# Altium schematic sheet Controle Moteurs.SchDoc
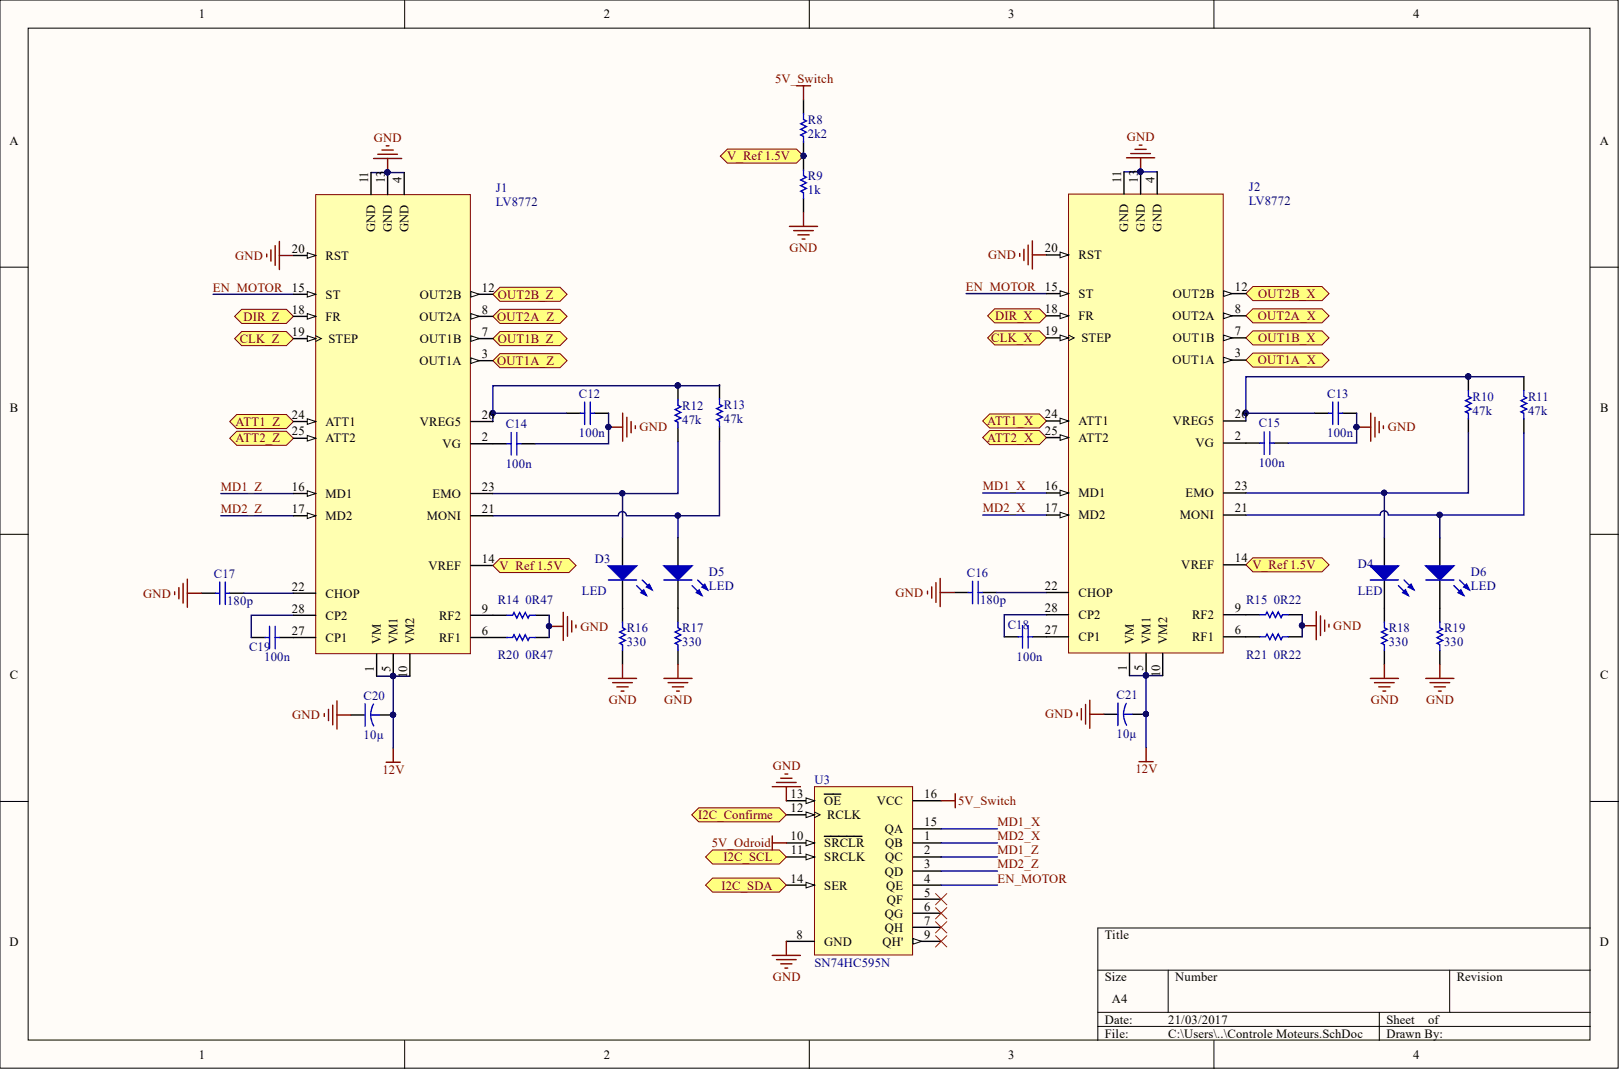
[diagram p0: Controle Moteurs.SchDoc]

## Parts
C12  value 100n  [RAD-0.3]  (hidden comment: Cap)
C13  value 100n  [RAD-0.3]  (hidden comment: Cap)
C14  value 100n  [RAD-0.3]  (hidden comment: Cap)
C15  value 100n  [RAD-0.3]  (hidden comment: Cap)
C16  value 180p  [RAD-0.3]  (hidden comment: Cap)
C17  value 180p  [RAD-0.3]  (hidden comment: Cap)
C18  value 100n  [RAD-0.3]  (hidden comment: Cap)
C19  value 100n  [RAD-0.3]  (hidden comment: Cap)
C20  value 10µ  [CAPR5-4X5]  (hidden comment: Cap2)
C21  value 10µ  [CAPR5-4X5]  (hidden comment: Cap2)
D3  LED  [C0805]
D4  LED  [C0805]
D5  LED  [C0805]
D6  LED  [C0805]
J1  LV8772
J2  LV8772
R8  value 2k2  [AXIAL-0.3]  (hidden comment: Res1)
R9  value 1k  [AXIAL-0.3]  (hidden comment: Res1)
R10  value 47k  [AXIAL-0.3]  (hidden comment: Res1)
R11  value 47k  [AXIAL-0.3]  (hidden comment: Res1)
R12  value 47k  [AXIAL-0.3]  (hidden comment: Res1)
R13  value 47k  [AXIAL-0.3]  (hidden comment: Res1)
R14  value 0R47  [AXIAL-0.3]  (hidden comment: Res1)
R15  value 0R22  [AXIAL-0.3]  (hidden comment: Res1)
R16  value 330  [AXIAL-0.3]  (hidden comment: Res1)
R17  value 330  [AXIAL-0.3]  (hidden comment: Res1)
R18  value 330  [AXIAL-0.3]  (hidden comment: Res1)
R19  value 330  [AXIAL-0.3]  (hidden comment: Res1)
R20  value 0R47  [AXIAL-0.3]  (hidden comment: Res1)
R21  value 0R22  [AXIAL-0.3]  (hidden comment: Res1)
U3  SN74HC595N  [N016]

## Ports
ATT1_X, ATT1_Z, ATT2_X, ATT2_Z, CLK_X, CLK_Z, DIR_X, DIR_Z, I2C_CONFIRME, I2C_SCL, I2C_SDA, OUT1A_X, OUT1A_Z, OUT1B_X, OUT1B_Z, OUT2A_X, OUT2A_Z, OUT2B_X, OUT2B_Z, V_REF 1.5V

## Nets
5V_ODROID: U3.10(SRCLR)
5V_SWITCH: R8.1 U3.16(VCC)
12V: C20.2 C21.2 J1.1(VM) J1.5(VM1) J1.10(VM2) J2.1(VM) J2.5(VM1) J2.10(VM2)
GND: C12.2 C13.2 C14.1 C15.1 C16.2 C17.2 C20.1 C21.1 J1.4(GND) J1.11(GND) J1.13(GND) J1.20(RST) J2.4(GND) J2.11(GND) J2.13(GND) J2.20(RST) R9.2 R14.1 R15.1 R16.2 R17.2 R18.2 R19.2 R20.1 R21.1 U3.8(GND) U3.13(OE)
ATT1_X: J2.24(ATT1) ; port ATT1_X
ATT1_Z: J1.24(ATT1) ; port ATT1_Z
ATT2_X: J2.25(ATT2) ; port ATT2_X
ATT2_Z: J1.25(ATT2) ; port ATT2_Z
CLK_X: J2.19(STEP) ; port CLK_X
CLK_Z: J1.19(STEP) ; port CLK_Z
DIR_X: J2.18(FR) ; port DIR_X
DIR_Z: J1.18(FR) ; port DIR_Z
EN_MOTOR: J1.15(ST) J2.15(ST) U3.4(QE)
I2C_SCL: U3.11(SRCLK) ; port I2C_SCL
I2C_SDA: U3.14(SER) ; port I2C_SDA
MD1_X: J2.16(MD1) U3.15(QA)
MD1_Z: J1.16(MD1) U3.2(QC)
MD2_X: J2.17(MD2) U3.1(QB)
MD2_Z: J1.17(MD2) U3.3(QD)
OUT1A_X: J2.3(OUT1A) ; port OUT1A_X
OUT1A_Z: J1.3(OUT1A) ; port OUT1A_Z
OUT1B_X: J2.7(OUT1B) ; port OUT1B_X
OUT1B_Z: J1.7(OUT1B) ; port OUT1B_Z
OUT2A_X: J2.8(OUT2A) ; port OUT2A_X
OUT2A_Z: J1.8(OUT2A) ; port OUT2A_Z
OUT2B_X: J2.12(OUT2B) ; port OUT2B_X
OUT2B_Z: J1.12(OUT2B) ; port OUT2B_Z
NetC12_1: C12.1 J1.26(VREG5) R12.1 R13.1
NetC13_1: C13.1 J2.26(VREG5) R10.1 R11.1
NetC14_2: C14.2 J1.2(VG)
NetC15_2: C15.2 J2.2(VG)
NetC16_1: C16.1 J2.22(CHOP)
NetC17_1: C17.1 J1.22(CHOP)
NetC18_1: C18.1 J2.27(CP1)
NetC18_2: C18.2 J2.28(CP2)
NetC19_1: C19.1 J1.27(CP1)
NetC19_2: C19.2 J1.28(CP2)
NetD3_1: D3.1 J1.23(EMO) R12.2
NetD3_2: D3.2 R16.1
NetD4_1: D4.1 J2.23(EMO) R10.2
NetD4_2: D4.2 R18.1
NetD5_1: D5.1 J1.21(MONI) R13.2
NetD5_2: D5.2 R17.1
NetD6_1: D6.1 J2.21(MONI) R11.2
NetD6_2: D6.2 R19.1
NetJ1_6: J1.6(RF1) R20.2
NetJ1_9: J1.9(RF2) R14.2
NetJ1_14: J1.14(VREF) J2.14(VREF) R8.2 R9.1 ; port V_REF 1.5V
NetJ2_6: J2.6(RF1) R21.2
NetJ2_9: J2.9(RF2) R15.2
NetU3_12: U3.12(RCLK) ; port I2C_CONFIRME

Not connected: U3 5(QF) 6(QG) 7(QH) 9(QH')

## Library data of the parts
C12-C15 C18 C19 = Cap: 100n; Component Kind: Standard; LatestRevisionDate: 17-Jul-2002; LatestRevisionNote: Re-released for DXP Platform.; Library Name: Miscellaneous Devices.IntLib; PackageReference: RAD-0.3; Published: 8-Jun-2000; Signal Integrity: Cap; Simulation: CAP; Publisher: Altium Limited
C16 C17 = Cap: 180p; Component Kind: Standard; LatestRevisionDate: 17-Jul-2002; LatestRevisionNote: Re-released for DXP Platform.; Library Name: Miscellaneous Devices.IntLib; PackageReference: RAD-0.3; Published: 8-Jun-2000; Signal Integrity: Cap; Simulation: CAP; Publisher: Altium Limited
C20 C21 = Cap2: 10µ; Component Kind: Standard; Library Name: Miscellaneous Devices.IntLib; PackageReference: CAPR5-4X5; Published: 23-Sep-2002; Signal Integrity: Cap; Simulation: CAP; Publisher: Altium Limited
D3-D6 = LED1: LED Rouge; Component Kind: Standard; LatestRevisionDate: 08-Jul-2005; LatestRevisionNote: Mech Layer 1 removed.; Library Name: Miscellaneous Devices.IntLib; PackageReference: LED-1; Published: 8-Jun-2000; Simulation: LED1; Publisher: Altium Limited
J1 J2 = LV8772: Driver Pas à Pas; Component Kind: Standard; Library Name: lib_Gabriel.SchLib
R8 = Res1: 2.2k; Component Kind: Standard; LatestRevisionDate: 17-Jul-2002; LatestRevisionNote: Re-released for DXP Platform.; Library Name: Miscellaneous Devices.IntLib; PackageReference: AXIAL-0.3; Published: 8-Jun-2000; Signal Integrity: Res; Simulation: RESISTOR; Publisher: Altium Limited
R9 = Res1: 1k; Component Kind: Standard; LatestRevisionDate: 17-Jul-2002; LatestRevisionNote: Re-released for DXP Platform.; Library Name: Miscellaneous Devices.IntLib; PackageReference: AXIAL-0.3; Published: 8-Jun-2000; Signal Integrity: Res; Simulation: RESISTOR; Publisher: Altium Limited
R10-R13 = Res1: 47k; Component Kind: Standard; LatestRevisionDate: 17-Jul-2002; LatestRevisionNote: Re-released for DXP Platform.; Library Name: Miscellaneous Devices.IntLib; PackageReference: AXIAL-0.3; Published: 8-Jun-2000; Signal Integrity: Res; Simulation: RESISTOR; Publisher: Altium Limited
R14 R20 = Res1: 470m; Component Kind: Standard; LatestRevisionDate: 17-Jul-2002; LatestRevisionNote: Re-released for DXP Platform.; Library Name: Miscellaneous Devices.IntLib; PackageReference: AXIAL-0.3; Published: 8-Jun-2000; Signal Integrity: Res; Simulation: RESISTOR; Publisher: Altium Limited
R15 R21 = Res1: 220m; Component Kind: Standard; LatestRevisionDate: 17-Jul-2002; LatestRevisionNote: Re-released for DXP Platform.; Library Name: Miscellaneous Devices.IntLib; PackageReference: AXIAL-0.3; Published: 8-Jun-2000; Signal Integrity: Res; Simulation: RESISTOR; Publisher: Altium Limited
R16-R19 = Res1: 330R; Component Kind: Standard; LatestRevisionDate: 17-Jul-2002; LatestRevisionNote: Re-released for DXP Platform.; Library Name: Miscellaneous Devices.IntLib; PackageReference: AXIAL-0.3; Published: 8-Jun-2000; Signal Integrity: Res; Simulation: RESISTOR; Publisher: Altium Limited
U3 = SN74HC595N: 8-Bit Shift Register with 3-State Output Registers; Code_JEDEC: MS-001-BB; Component Kind: Standard; ComponentLink1Description: Manufacturer Link; ComponentLink1URL: http://www.ti.com/; ComponentLink2Description: Datasheet; ComponentLink2URL: http://www-s.ti.com/sc/ds/sn74hc595.pdf; DatasheetVersion: May-1997; LatestRevisionDate: 04-Mar-2005; LatestRevisionNote: Stylized 3D Model Added.; Library Name: TI Logic Register.IntLib; PackageDescription: DIP; 16 Leads; Row Spacing 7.62 mm; Pitch 2.54 mm; PackageReference: N016; PackageVersion: Oct-1995; PCB3D: N016; Published: 8-Jun-2000; Signal Integrity: SN74HC595N; Publisher: Altium Limited
